# Altium schematic sheet [2]- Connector_Page.SchDoc("[2]- Connector_Page")
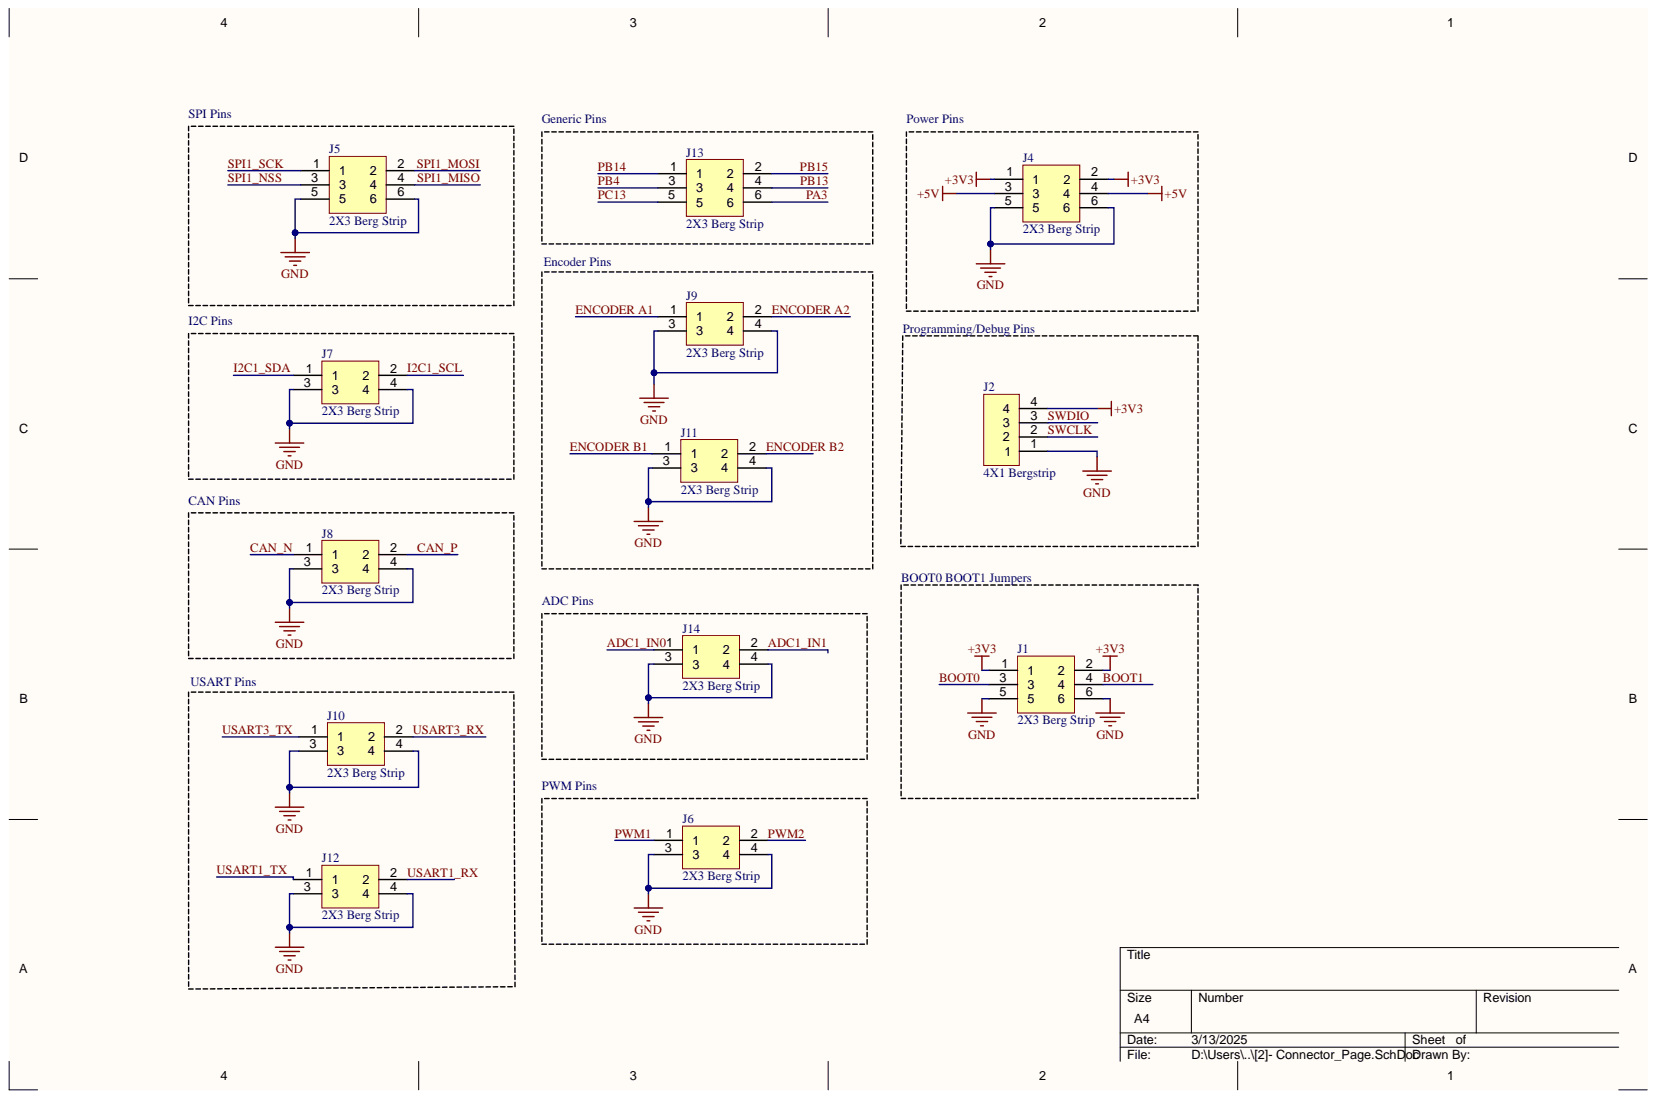
[diagram p0: [2]- Connector_Page.SchDoc]

## Parts
J1  2X3 Berg Strip  [CON6_100_59D78_TH_ST_Duplicate]
J2  4X1 Bergstrip  [CON4_100_40D72_TH_ST_MALE]
J4  2X3 Berg Strip  [CON6_100_59D78_TH_ST_Duplicate]
J5  2X3 Berg Strip  [CON6_100_59D78_TH_ST_Duplicate]
J6  2X3 Berg Strip  [CON4_100_59D78_TH_ST_Duplicate]
J7  2X3 Berg Strip  [CON4_100_59D78_TH_ST_Duplicate]
J8  2X3 Berg Strip  [CON4_100_59D78_TH_ST_Duplicate]
J9  2X3 Berg Strip  [CON4_100_59D78_TH_ST_Duplicate]
J10  2X3 Berg Strip  [CON4_100_59D78_TH_ST_Duplicate]
J11  2X3 Berg Strip  [CON4_100_59D78_TH_ST_Duplicate]
J12  2X3 Berg Strip  [CON4_100_59D78_TH_ST_Duplicate]
J13  2X3 Berg Strip  [CON6_100_59D78_TH_ST_Duplicate]
J14  2X3 Berg Strip  [CON4_100_59D78_TH_ST_Duplicate]

## Nets
+3V3: J1.1 J1.2 J2.4 J4.1 J4.2
+5V: J4.3 J4.4
GND: J1.5 J1.6 J2.1 J4.5 J4.6 J5.5 J5.6 J6.3 J6.4 J7.3 J7.4 J8.3 J8.4 J9.3 J9.4 J10.3 J10.4 J11.3 J11.4 J12.3 J12.4 J14.3 J14.4
ADC1_IN0: J14.1
ADC1_IN1: J14.2
BOOT0: J1.3
BOOT1: J1.4
CAN_N: J8.1
CAN_P: J8.2
ENCODER A1: J9.1
ENCODER A2: J9.2
ENCODER B1: J11.1
ENCODER B2: J11.2
I2C1_SCL: J7.2
I2C1_SDA: J7.1
PA3: J13.6
PB4: J13.3
PB13: J13.4
PB14: J13.1
PB15: J13.2
PC13: J13.5
PWM1: J6.1
PWM2: J6.2
SPI1_MISO: J5.4
SPI1_MOSI: J5.2
SPI1_NSS: J5.3
SPI1_SCK: J5.1
SWCLK: J2.2
SWDIO: J2.3
USART1_RX: J12.2
USART1_TX: J12.1
USART3_RX: J10.2
USART3_TX: J10.1

## Library data of the parts
J1 J4 J5 J13 = CON-BS-PLG_2X3-100-TH-ST: 6 pin, 2 row, 2.54mm pitch bergstrip; Manufacturer: NA; Case/Package: TH; Component Kind: Standard; Library Name: STM_SCH_LIB.SchLib; Manufacturer Part Number: NA; Supplier Part Number-1: NA; Supplier-1: NA
J2 = CON-BS-PLG_4X1-100-TH-ST: 4 pin, 1 row, 2.54mm pitch bergstrip; Manufacturer: NA; Case/Package: TH; Component Kind: Standard; Library Name: STM_SCH_LIB.SchLib; Manufacturing Part Number: NA; Supplier Part Number-1: NA; Supplier-1: NA
J6-J12 J14 = CON-BS-PLG_2X2-100-TH-ST: 4 pin, 2 row, 2.54mm pitch bergstrip; Component Kind: Standard; Library Name: STM_SCH_LIB.SchLib
